# Altium schematic sheet Measurement.SchDoc(Measurement)
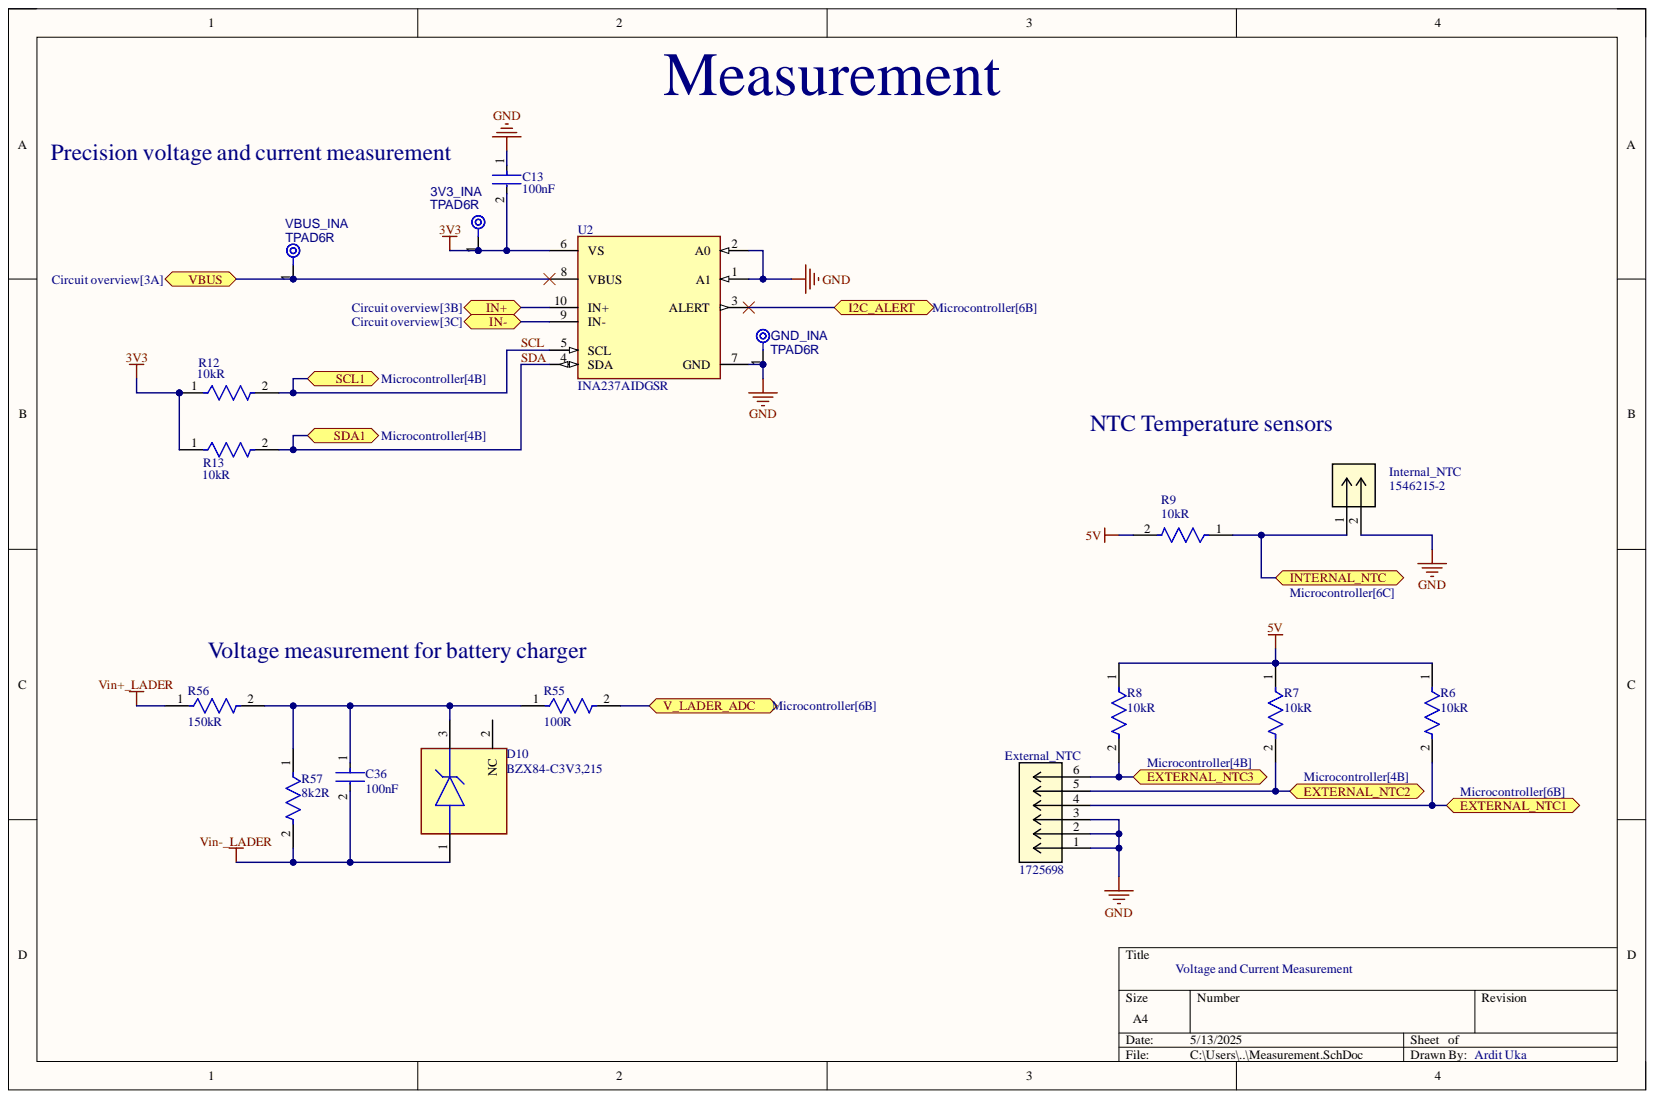
[diagram p0: Measurement.SchDoc]

## Parts
3V3_INA  TPAD6R  [TP06R]  (no BOM)
C13  Capacitance: 100nF  [FP-0603-L_1_6_0_15-W_0_81-IPC_C]  (hidden comment: 06035C104K4T2A)
C36  100nF  [FP-CL10-IPC_A]
D10  BZX84-C3V3,215  [FP-SOT23-MFG]
External_NTC  1725698  [CONN_1725698_PXC]
GND_INA  TPAD6R  [TP06R]  (no BOM)
Internal_NTC  1546215-2  [CONN_1546215-2_TEC]
R6  Resistance: 10kR  [FP-MCT0603-IPC_C]  (hidden comment: MCT06030C1002FP500)
R7  Resistance: 10kR  [FP-MCT0603-IPC_C]  (hidden comment: MCT06030C1002FP500)
R8  Resistance: 10kR  [FP-MCT0603-IPC_C]  (hidden comment: MCT06030C1002FP500)
R9  Resistance: 10kR  [FP-MCT0603-IPC_C]  (hidden comment: MCT06030C1002FP500)
R12  Resistance: 10kR  [FP-MCT0603-IPC_C]  (hidden comment: MCT06030C1002FP500)
R13  Resistance: 10kR  [FP-MCT0603-IPC_C]  (hidden comment: MCT06030C1002FP500)
R55  100R  [FP-ERA3A-IPC_B]
R56  150kR  [FP-CRCW0603-e3-IPC_C]
R57  8k2R  [FP-RT0603-MFG]
U2  INA237AIDGSR  [FP-DGS0010A-MFG]
VBUS_INA  TPAD6R  [TP06R]  (no BOM)

## Ports
EXTERNAL_NTC1, EXTERNAL_NTC2, EXTERNAL_NTC3, I2C_ALERT, IN+, IN-, INTERNAL_NTC, SCL1, SDA1, V_LADER_ADC, VBUS

## Nets
3V3: 3V3_INA.1 C13.2 R12.1 R13.1 U2.6(VS)
5V: R6.1 R7.1 R8.1 R9.2
GND: C13.1 External_NTC.1 External_NTC.2 External_NTC.3 GND_INA.1 Internal_NTC.2 U2.1(A1) U2.2(A0) U2.7(GND)
Vin+_LADER: R56.1
Vin-_LADER: C36.2 D10.1 R57.2
EXTERNAL_NTC1: External_NTC.4 R6.2 ; port EXTERNAL_NTC1
EXTERNAL_NTC2: External_NTC.5 R7.2 ; port EXTERNAL_NTC2
EXTERNAL_NTC3: External_NTC.6 R8.2 ; port EXTERNAL_NTC3
I2C_ALERT: U2.3(ALERT) ; port I2C_ALERT
INTERNAL_NTC: Internal_NTC.1 R9.1 ; port INTERNAL_NTC
SCL: R12.2 U2.5(SCL) ; port SCL1
SDA: R13.2 U2.4(SDA) ; port SDA1
V_LADER_ADC: R55.2 ; port V_LADER_ADC
VBUS: U2.8(VBUS) VBUS_INA.1 ; port VBUS
NetC36_1: C36.1 D10.3 R55.1 R56.2 R57.1
NetU2_9: U2.9(IN-)
NetU2_10: U2.10(IN+)

Not connected: D10 2

## Library data of the parts
3V3_INA GND_INA VBUS_INA = CMP-00028-00002-2: 0.6mm round; Pins: 1; Library Name: Sondre Pettersen
C13 = CMP-2006-04386-2: Automotive Ceramic Capacitor, 0603, 100nF, 10%, X7R, 15%, 50V; Dissipation Factor: 2.5%; Insulation Resistance: 100GR; Case Code (Imperial): 0603; Case Code (Metric): 1608; Case/Package: 0603; Component Kind: Standard; Construction: Flat; Contact Plating: Tin; Depth: 0.81mm; Dielectric: X7R; Dielectric Material: Ceramic; Height: 0.9mm; Lead Free: Lead Free; Length: 1.6mm; Library Name: Altium Content Vault; Max Operating Temperature: 125°C; Min Operating Temperature: -55°C; Mount: Surface Mount; Number of Pins: 2; Package Quantity: 1; Packaging: Tape and Reel; Radiation Hardening: No; Ratings: AEC-Q200; REACH SVHC: No SVHC; RoHS Compliant: Yes; Series: -; Termination: SMD/SMT; Thickness: 0.035inch; Tolerance: 10%; Voltage: 50V; Voltage Rating: 50V; Voltage Rating (DC): 50V; Width: 0.032inch
C36 = CMP-13271-004036-1: Cap Ceramic 100nF 100V X7R ±10% Pad SMD 0603 +125°C T/R; Capacitance: 100nF; Case Code (Imperial): 0603; Case Code (Metric): 1608; Case/Package: 0603; Component Kind: Standard; Length: 0.063inch; Library Name: Altium Content Vault; Max Operating Temperature: 125°C; Min Operating Temperature: -55°C; Mount: Surface Mount; Packaging: Cut Tape; Thickness: 0.035inch; Tolerance: 10%; Voltage Rating: 100V; Width: 0.031inch
D10 = CMP-14400-000006-1: DIODE ZENER 3.3V 250MW SOT23; Manufacturer: Nexperia; Automotive Grade: Grade 0; Forward Current: 200mA; Max Junction Temp: +150°C; Max Reverse Leakage Current: 5uA; Max Zener Voltage: 3.5V; Reverse Voltage: 1V; Zener Impedance: 350Ω; Ambient Temperature Range High: +150°C; Automotive: Yes; Category: Diode; Component Kind: Standard; ComponentLink2Description: Datasheet; ComponentLink2URL: https://assets.nexperia.com/documents/data-sheet/BZX84_SER.pdf; Device Class L1: Discrete Semiconductors; Device Class L2: Diodes; Device Class L3: Zener Diodes; Digikey Description: DIODE ZENER 3.3V 250MW SOT23; Footprint Url: https://assets.nexperia.com/documents/outline-drawing/SOT23.pdf; Height: 1.1mm; Lead Free: Yes; Library Name: Altium Content Vault; Manufacturer Part Number: BZX84-C3V3,215; Nom Zener Voltage: 3.3V; Octopart: https://octopart.com/bzx84-c3v3%2C215-nexperia-78740243; Package: SOT23; Power Dissipation: 250mW; RoHS: TRUE; Temperature: -65°C to +150°C; Temperature Range High: +150°C; Temperature Range Low: -65°C
External_NTC = 1725698: No Description Available; Mfr_Name: Phoenix Contact; Component Kind: Standard; Library Name: 1725698.IntLib; RefDes: RefDes; Type: Type
Internal_NTC = 1546215-2: No Description Available; Mfr_Name: TE Connectivity; Component Kind: Standard; Library Name: 1546215-2.IntLib; RefDes: RefDes; Type: Type
R6-R9 R12 R13 = CMP-02407-000418-1: RES SMD 100 OHM 1% 1/8W 0603; Max Power Dissipation: 125mW; Power Rating: 100mW; Temperature Coefficient: 50ppm/°C; Voltage Rating: 75V; Case Code (Imperial): 0603; Case Code (Metric): 1608; Case/Package: 0603; Component Kind: Standard; Composition: Thin Film; Contact Plating: Tin; Depth: 0.85mm; Height: 0.022inch; Lead Free: Lead Free; Length: 1.55mm; Library Name: Altium Content Vault; Max Operating Temperature: 125°C; Military Standard: Not; Min Operating Temperature: -55°C; Mount: Surface Mount; Number of Terminations: 2; Package Quantity: 1; Packaging: Tape and Reel; Radiation Hardening: No; Tolerance: 1%; Voltage Rating (DC): 75V; Width: 0.8mm
R55 = CMP-2000-00870-2: RES SMD 100 OHM 0.1% 1/10W 0603; Max Power Dissipation: 100mW; Temperature Coefficient: 25ppm/°C; Voltage Rating: 75V; Case Code (Imperial): 0603; Case Code (Metric): 1608; Case/Package: 0603; Component Kind: Standard; Construction: Rectangular; Contact Plating: Tin; Depth: 0.8mm; Height: 0.45mm; Length: 1.6mm; Library Name: Altium Content Vault; Max Operating Temperature: 155°C; Military Standard: Not; Min Operating Temperature: -55°C; Mount: Surface Mount; Number of Pins: 2; Number of Terminations: 2; Package Quantity: 5000; Packaging: Tape and Reel; Power Rating: 100mW; Radiation Hardening: No; REACH SVHC: No SVHC; Resistance: 100R; Resistance Tolerance: 0.1%; Resistor Type: Thin Film; RoHS Compliant: Yes; Series: ERA-3A; Size Code: 0603; Technology: METAL FILM; Termination: SMD/SMT; Tolerance: 0.1%; Width: 0.8mm
R56 = CMP-2000-03910-2: RES Thick Film, 150kΩ, 1%, 0.1W, 100ppm/°C, 0603; Power Rating: 100mW; Voltage Rating: 75V; Case Code (Imperial): 0603; Case Code (Metric): 1608; Case/Package: 0603; Component Kind: Standard; Composition: Thick Film; Contact Plating: Tin; Depth: 0.85mm; Height: 0.45mm; Lead Free: Lead Free; Length: 1.55mm; Library Name: Altium Content Vault; Max Operating Temperature: 155°C; Military Standard: Not; Min Operating Temperature: -55°C; Mount: Surface Mount; Package Quantity: 5000; Packaging: Tape and Reel; Resistance: 150kR; Temperature Coefficient: 100ppm/°C; Termination: SMD/SMT; Tolerance: 1%; Voltage Rating (DC): 75V; Weight: 7.1E-05oz; Width: 0.85mm
R57 = CMP-2000-04507-2: RES 8.20K OHM 1/10W .1% SMD 0603; Manufacturer: Yageo; Manufacturer Part Number: RT0603BRD078K2L; Power Rating: 0.1W; Resistance: 8.2KΩ; Temperature Coefficient: 25 ppm/°C; Tolerance: 0.001; Value: 8.2KΩ; Voltage Rating: 75V; Automotive: No; Category: Res; Component Kind: Standard; ComponentLink2Description: Datasheet; ComponentLink2URL: http://www.yageo.com/documents/recent/PYu-RT_1-to-0.01_RoHS_L_9.pdf; Composition: Thin Film; Device Class L1: Passive Components; Device Class L2: Resistors; Device Class L3: Chip SMD Resistors; Digikey Description: RES 8.20K OHM 1/10W .1% SMD 0603; Footprint Url: http://www.yageo.com/exep/pages/download/literatures/PYu-R_Mount_10.pdf; Height: 0.55mm; Ipc Land Pattern Name: RESC16080X45; Lead Free: Yes; Library Name: Altium Content Vault; Mouser Description: Thin Film Resistors - SMD 1/10W 8.2K ohm .1% 25ppm; Octopart: https://octopart.com/rt0603brd078k2l-yageo-1264981; Package: RT0603; RoHS: TRUE; Series: RT; Temperature: -55°C to +155°C; Temperature Range High: +155°C; Temperature Range Low: -55°C
U2 = CMP-04895-000433-1: 85-V, 16-BIT, I2C OUTPUT CURRENT; Component Kind: Standard; Library Name: Altium Content Vault
